# Altium schematic sheet Sheet6.SchDoc
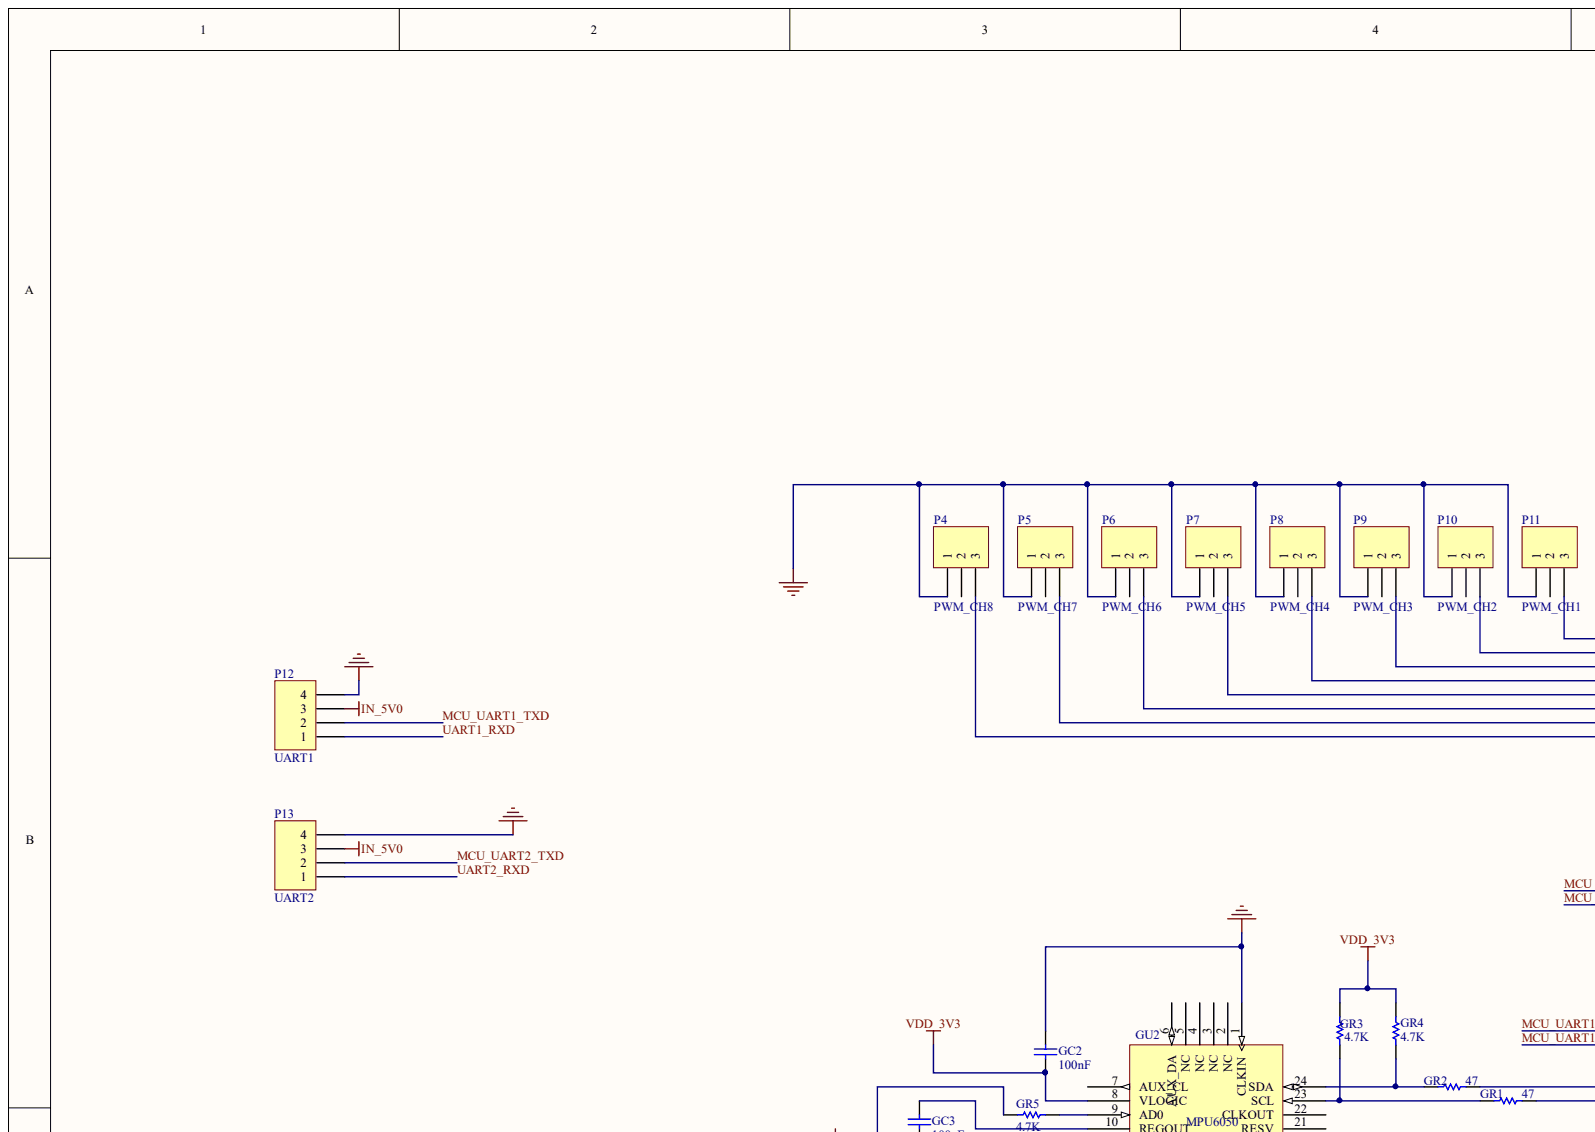
[diagram p0: Sheet6.SchDoc, top left]
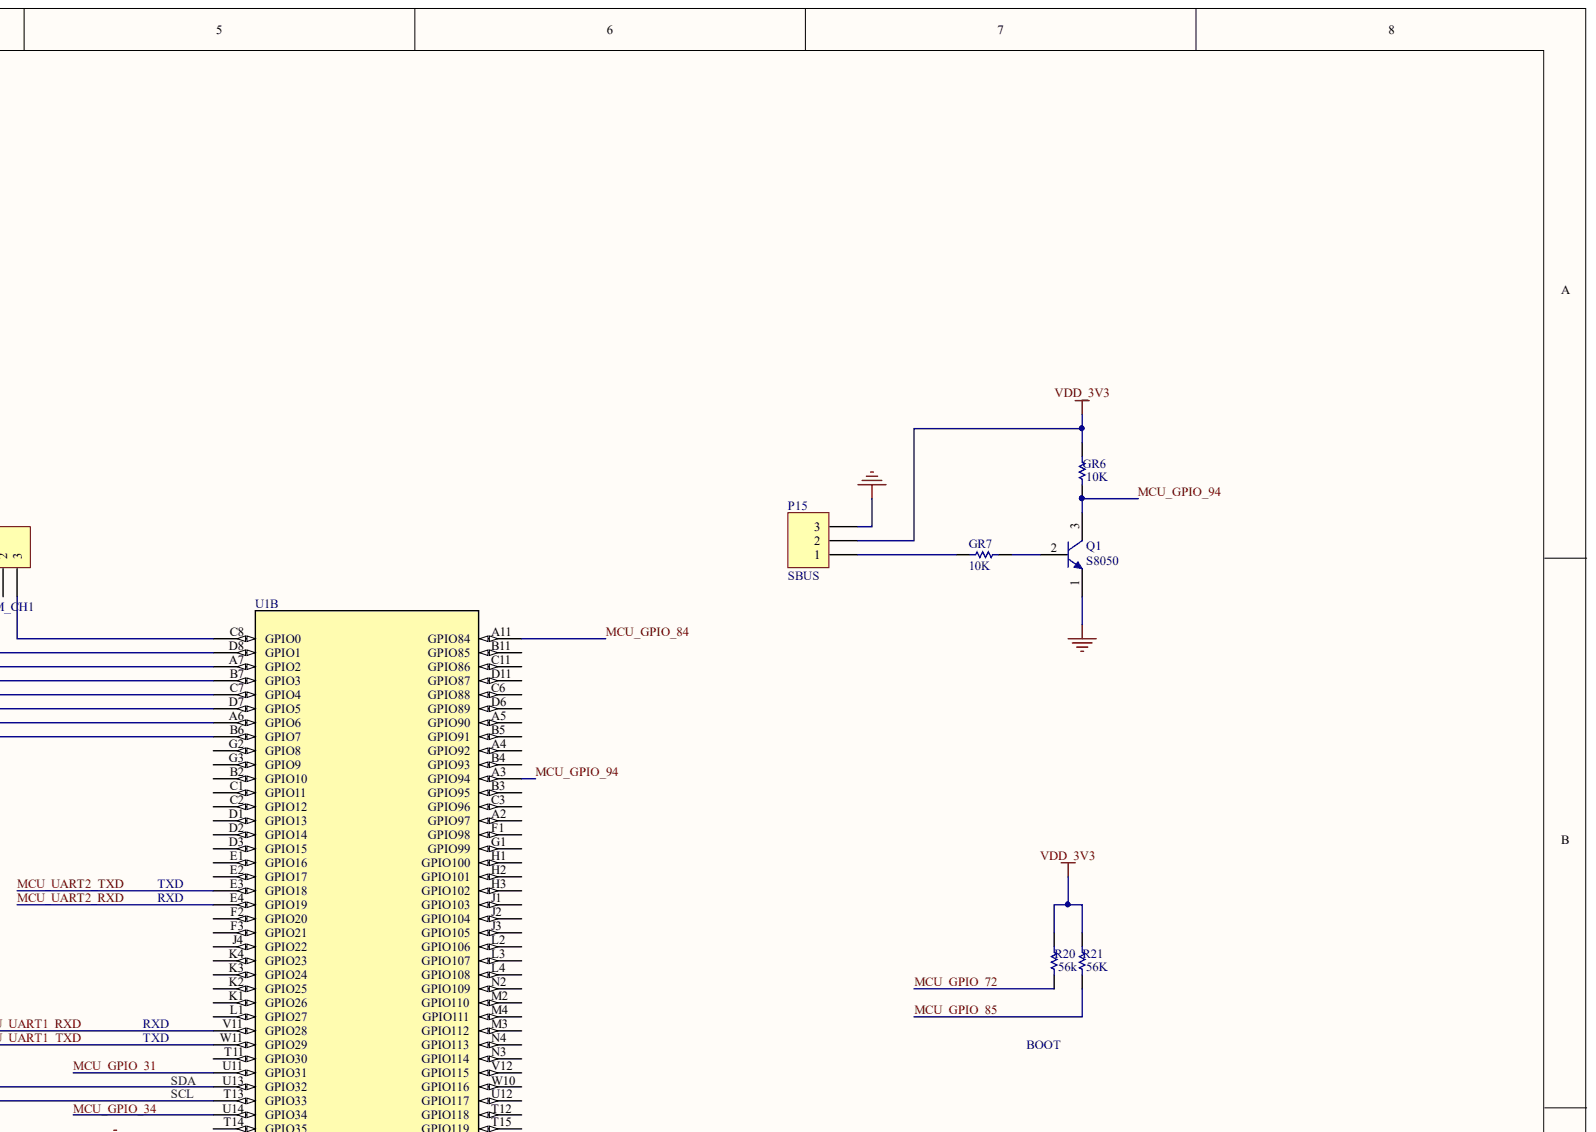
[diagram p1: Sheet6.SchDoc, top right]
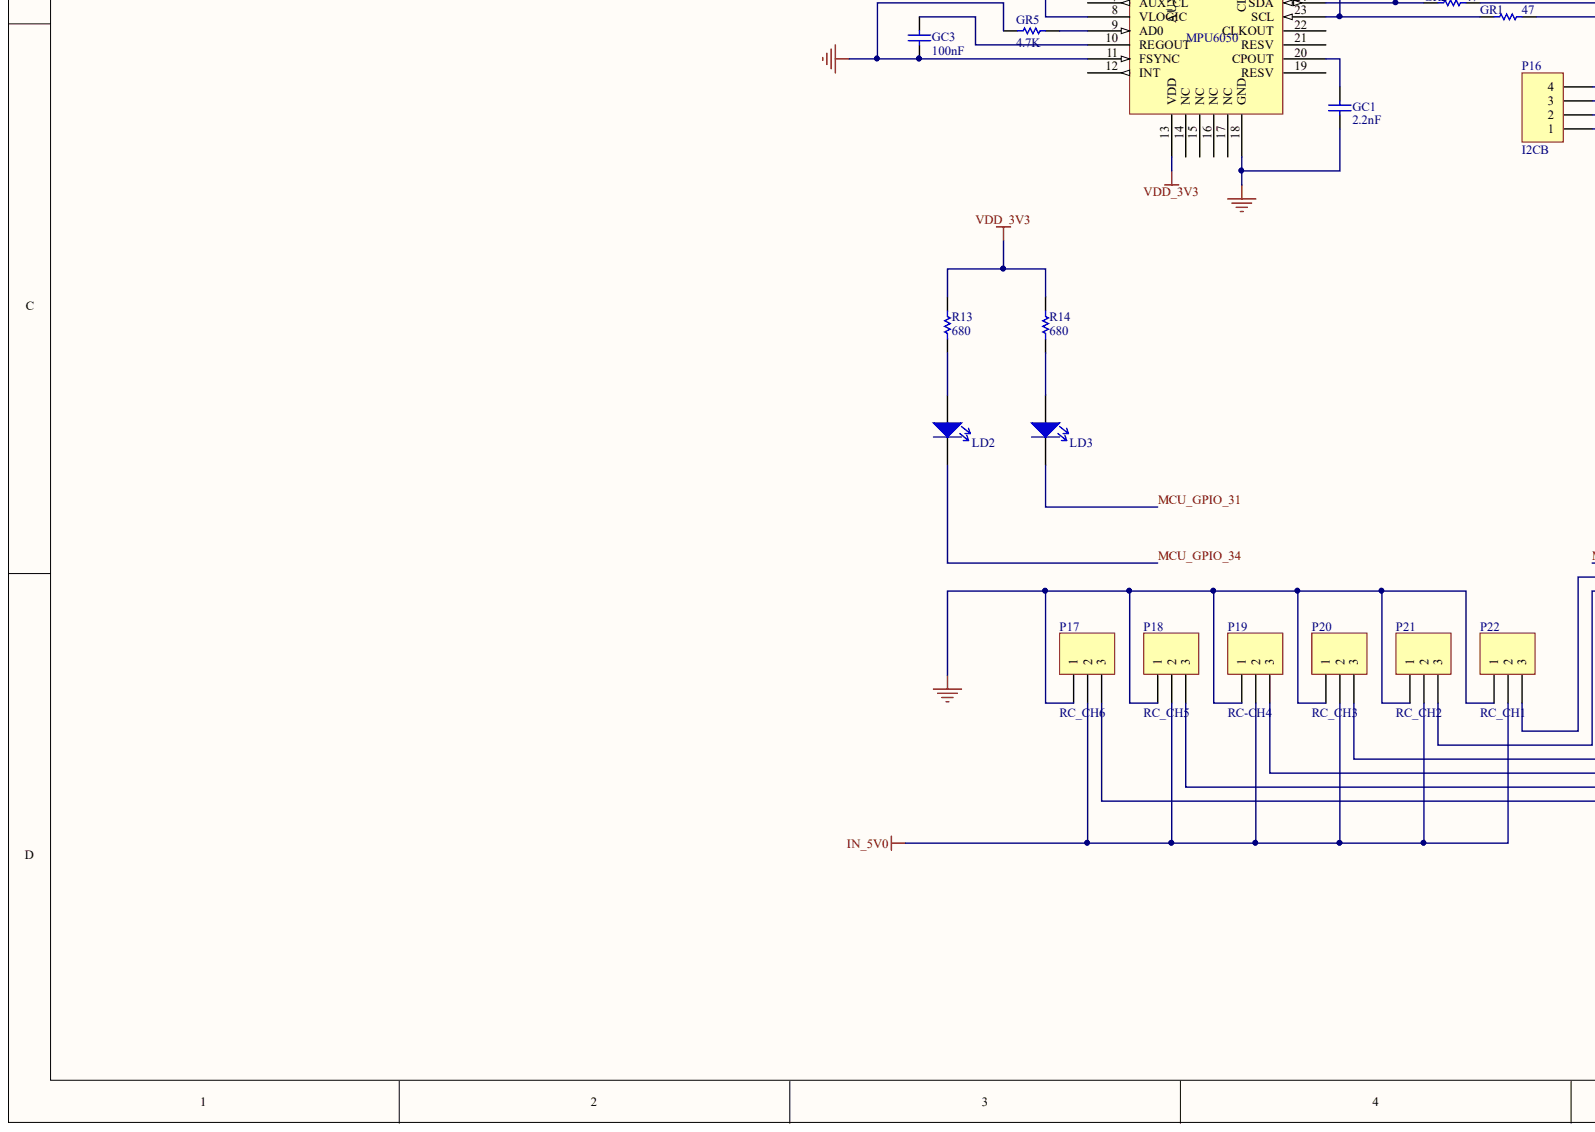
[diagram p2: Sheet6.SchDoc, bottom left]
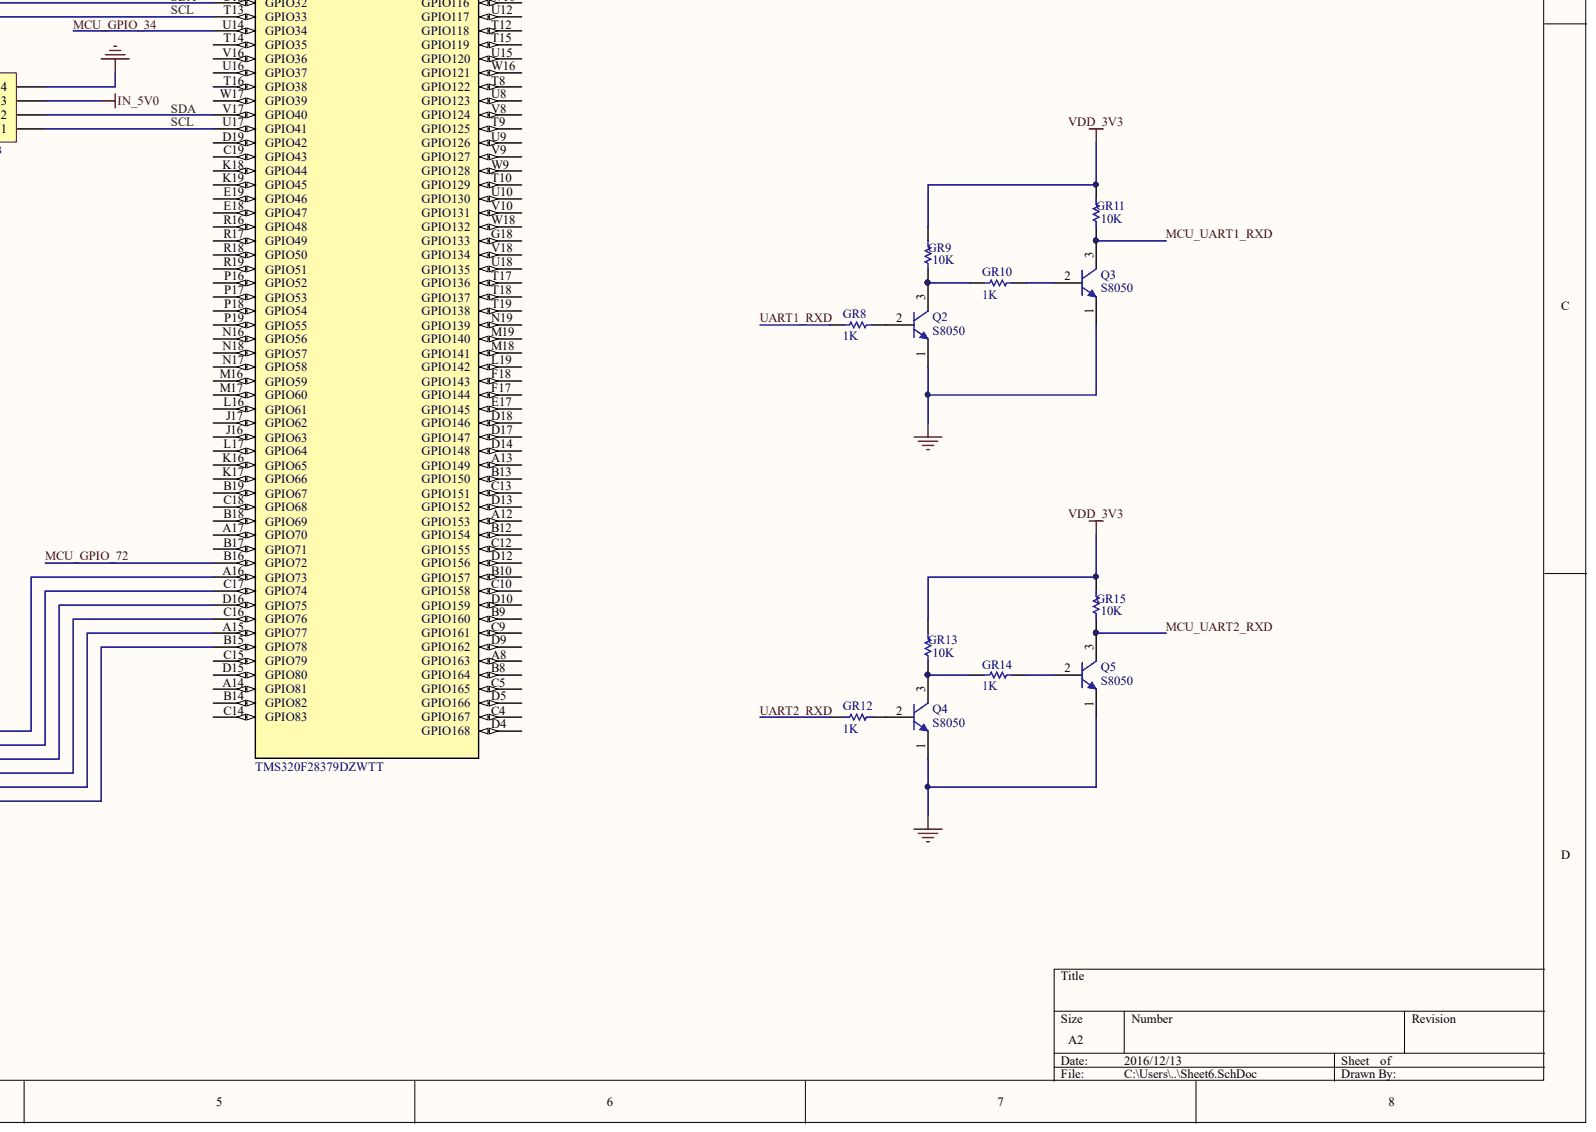
[diagram p3: Sheet6.SchDoc, bottom right]

## Parts
GC1  value 2.2nF  [0805_TW]  (hidden comment: C_0805)
GC2  value 100nF  [0805_TW]  (hidden comment: C_0805)
GC3  value 100nF  [0805_TW]  (hidden comment: C_0805)
GR1  value 47  [0402_TW]  (hidden comment: R_0402)
GR2  value 47  [0402_TW]  (hidden comment: R_0402)
GR3  value 4.7K  [0402_TW]  (hidden comment: R_0402)
GR4  value 4.7K  [0402_TW]  (hidden comment: R_0402)
GR5  value 4.7K  [0402_TW]  (hidden comment: R_0402)
GR6  value 10K  [0402_TW]  (hidden comment: R_0402)
GR7  value 10K  [0402_TW]  (hidden comment: R_0402)
GR8  value 1K  [0402_TW]  (hidden comment: R_0402)
GR9  value 10K  [0402_TW]  (hidden comment: R_0402)
GR10  value 1K  [0402_TW]  (hidden comment: R_0402)
GR11  value 10K  [0402_TW]  (hidden comment: R_0402)
GR12  value 1K  [0402_TW]  (hidden comment: R_0402)
GR13  value 10K  [0402_TW]  (hidden comment: R_0402)
GR14  value 1K  [0402_TW]  (hidden comment: R_0402)
GR15  value 10K  [0402_TW]  (hidden comment: R_0402)
GU2  MPU6050
LD2  LED_Lights  [LED-0805_TW]  (value not drawn)
LD3  LED_Lights  [LED-0805_TW]  (value not drawn)
P4  PWM_CH8  [HDR1X3]
P5  PWM_CH7  [HDR1X3]
P6  PWM_CH6  [HDR1X3]
P7  PWM_CH5  [HDR1X3]
P8  PWM_CH4  [HDR1X3]
P9  PWM_CH3  [HDR1X3]
P10  PWM_CH2  [HDR1X3]
P11  PWM_CH1  [HDR1X3]
P12  UART1  [HDR1X4]
P13  UART2  [HDR1X4]
P15  SBUS  [HDR1X3]
P16  I2CB  [HDR1X4]
P17  RC_CH6  [HDR1X3]
P18  RC_CH5  [HDR1X3]
P19  RC-CH4  [HDR1X3]
P20  RC_CH3  [HDR1X3]
P21  RC_CH2  [HDR1X3]
P22  RC_CH1  [HDR1X3]
Q1  S8050  [TO-92A]
Q2  S8050  [TO-92A]
Q3  S8050  [TO-92A]
Q4  S8050  [TO-92A]
Q5  S8050  [TO-92A]
R13  value 680  [0402_TW]  (hidden comment: R_0402)
R14  value 680  [0402_TW]  (hidden comment: R_0402)
R20  value 56k  [0402_TW]  (hidden comment: R_0402)
R21  value 56K  [0402_TW]  (hidden comment: R_0402)
U1  TMS320F28379DZWTT  [ZWT0337A]  (part B)

## Nets
GND: GC1.2 GC2.1 GC3.2 GR5.2 GU2.1(CLKIN) GU2.11(FSYNC) GU2.18(GND) P4.1 P5.1 P6.1 P7.1 P8.1 P9.1 P10.1 P11.1 P12.4 P13.4 P15.3 P16.4 P17.1 P18.1 P19.1 P20.1 P21.1 P22.1 Q1.1 Q2.1 Q3.1 Q4.1 Q5.1
IN_5V0: P12.3 P13.3 P16.3 P17.2 P18.2 P19.2 P20.2 P21.2 P22.2
VDD_3V3: GC2.2 GR3.2 GR4.2 GR6.2 GR9.2 GR11.2 GR13.2 GR15.2 GU2.8(VLOGIC) GU2.13(VDD) P15.2 R13.2 R14.2 R20.2 R21.2
MCU_GPIO_31: LD3.2 U1.U11(GPIO31)
MCU_GPIO_34: LD2.2 U1.U14(GPIO34)
MCU_GPIO_72: R20.1 U1.B16(GPIO72)
MCU_GPIO_84: U1.A11(GPIO84)
MCU_GPIO_85: R21.1
MCU_GPIO_94: GR6.1 Q1.3 U1.A3(GPIO94)
MCU_UART1_RXD: GR11.1 Q3.3 U1.V11(GPIO28)
MCU_UART1_TXD: P12.2 U1.W11(GPIO29)
MCU_UART2_RXD: GR15.1 Q5.3 U1.E4(GPIO19)
MCU_UART2_TXD: P13.2 U1.E3(GPIO18)
UART1_RXD: GR8.2 P12.1
UART2_RXD: GR12.2 P13.1
NetGC1_1: GC1.1 GU2.20(CPOUT)
NetGC3_1: GC3.1 GU2.10(REGOUT)
NetGR1_1: GR1.1 GR3.1 GU2.23(SCL)
NetGR1_2: GR1.2 U1.T13(GPIO33)
NetGR2_1: GR2.1 GR4.1 GU2.24(SDA)
NetGR2_2: GR2.2 U1.U13(GPIO32)
NetGR5_1: GR5.1 GU2.9(AD0)
NetGR7_1: GR7.1 Q1.2
NetGR7_2: GR7.2 P15.1
NetGR8_1: GR8.1 Q2.2
NetGR9_1: GR9.1 GR10.2 Q2.3
NetGR10_1: GR10.1 Q3.2
NetGR12_1: GR12.1 Q4.2
NetGR13_1: GR13.1 GR14.2 Q4.3
NetGR14_1: GR14.1 Q5.2
NetLD2_1: LD2.1 R13.1
NetLD3_1: LD3.1 R14.1
NetP4_3: P4.3 U1.B6(GPIO7)
NetP5_3: P5.3 U1.A6(GPIO6)
NetP6_3: P6.3 U1.D7(GPIO5)
NetP7_3: P7.3 U1.C7(GPIO4)
NetP8_3: P8.3 U1.B7(GPIO3)
NetP9_3: P9.3 U1.A7(GPIO2)
NetP10_3: P10.3 U1.D8(GPIO1)
NetP11_3: P11.3 U1.C8(GPIO0)
NetP16_1: P16.1 U1.U17(GPIO41)
NetP16_2: P16.2 U1.V17(GPIO40)
NetP17_3: P17.3 U1.B15(GPIO78)
NetP18_3: P18.3 U1.A15(GPIO77)
NetP19_3: P19.3 U1.C16(GPIO76)
NetP20_3: P20.3 U1.D16(GPIO75)
NetP21_3: P21.3 U1.C17(GPIO74)
NetP22_3: P22.3 U1.A16(GPIO73)

Not connected: GU2 2(NC) 3(NC) 4(NC) 5(NC) 6(AUX_DA) 7(AUX_CL) 12(INT) 14(NC) 15(NC) 16(NC) 17(NC) 19(RESV) 21(RESV) 22(CLKOUT); P4 2; P5 2; P6 2; P7 2; P8 2; P9 2; P10 2; P11 2; U1 A2(GPIO97) A4(GPIO92) A5(GPIO90) A8(GPIO163) A12(GPIO153) A13(GPIO149) A14(GPIO81) A17(GPIO70) B2(GPIO10) B3(GPIO95) B4(GPIO93) B5(GPIO91) B8(GPIO164) B9(GPIO160) B10(GPIO157) B11(GPIO85) B12(GPIO154) B13(GPIO150) B14(GPIO82) B17(GPIO71) B18(GPIO69) B19(GPIO67) C1(GPIO11) C2(GPIO12) C3(GPIO96) C4(GPIO167) C5(GPIO165) C6(GPIO88) C9(GPIO161) C10(GPIO158) C11(GPIO86) C12(GPIO155) C13(GPIO151) C14(GPIO83) C15(GPIO79) C18(GPIO68) C19(GPIO43) D1(GPIO13) D2(GPIO14) D3(GPIO15) D4(GPIO168) D5(GPIO166) D6(GPIO89) D9(GPIO162) D10(GPIO159) D11(GPIO87) D12(GPIO156) D13(GPIO152) D14(GPIO148) D15(GPIO80) D17(GPIO147) D18(GPIO146) D19(GPIO42) E1(GPIO16) E2(GPIO17) E17(GPIO145) E18(GPIO47) E19(GPIO46) F1(GPIO98) F2(GPIO20) F3(GPIO21) F17(GPIO144) F18(GPIO143) G1(GPIO99) G2(GPIO8) G3(GPIO9) G18(GPIO133) H1(GPIO100) H2(GPIO101) H3(GPIO102) J1(GPIO103) J2(GPIO104) J3(GPIO105) J4(GPIO22) J16(GPIO63) J17(GPIO62) K1(GPIO26) K2(GPIO25) K3(GPIO24) K4(GPIO23) K16(GPIO65) K17(GPIO66) K18(GPIO44) K19(GPIO45) L1(GPIO27) L2(GPIO106) L3(GPIO107) L4(GPIO108) L16(GPIO61) L17(GPIO64) L19(GPIO142) M2(GPIO110) M3(GPIO112) M4(GPIO111) M16(GPIO59) M17(GPIO60) M18(GPIO141) M19(GPIO140) N2(GPIO109) N3(GPIO114) N4(GPIO113) N16(GPIO56) N17(GPIO58) N18(GPIO57) N19(GPIO139) P16(GPIO52) P17(GPIO53) P18(GPIO54) P19(GPIO55) R16(GPIO48) R17(GPIO49) R18(GPIO50) R19(GPIO51) T8(GPIO122) T9(GPIO125) T10(GPIO129) T11(GPIO30) T12(GPIO118) T14(GPIO35) T15(GPIO119) T16(GPIO38) T17(GPIO136) T18(GPIO137) T19(GPIO138) U8(GPIO123) U9(GPIO126) U10(GPIO130) U12(GPIO117) U15(GPIO120) U16(GPIO37) U18(GPIO135) V8(GPIO124) V9(GPIO127) V10(GPIO131) V12(GPIO115) V16(GPIO36) V18(GPIO134) W9(GPIO128) W10(GPIO116) W16(GPIO121) W17(GPIO39) W18(GPIO132)

## Library data of the parts
P4-P11 P15 P17-P22 = Header 3: Header, 3-Pin; Component Kind: Standard; LatestRevisionDate: 17-Jul-2002; LatestRevisionNote: Re-released for DXP Platform.; Library Name: Miscellaneous Connectors.IntLib; Signal Integrity: Connector; Publisher: Altium Limited
P12 P13 P16 = Header 4: Header, 4-Pin; Component Kind: Standard; LatestRevisionDate: 17-Jul-2002; LatestRevisionNote: Re-released for DXP Platform.; Library Name: Miscellaneous Connectors.IntLib; Signal Integrity: Connector; Publisher: Altium Limited
Q1-Q5 = S8050: NPN三极管; Code_JEDEC: TO-92A; Component Kind: Standard; ComponentLink1Description: Manufacturer Link; ComponentLink1URL: http://www.fairchildsemi.com/; ComponentLink2Description: Datasheet; ComponentLink2URL: http://www.fairchildsemi.com/ds/2N%2F2N3904.pdf; DatasheetDocument: 1997; LatestRevisionDate: 15-Jan-2003; LatestRevisionNote: PCB Footprint 'BCY-W3/D4.7' replaced; PackageDocument: Sep-1998; PackageReference: TO-92A; Published: 8-Jun-2000; Library Name: fszhang.SchLib; Publisher: Altium Limited
U1 = TMS320F28379DZWTT: Imported; Manufacturer: Texas Instruments; Component Kind: Standard; Library Name: TMS320F28379DZWTT.SchLib; PartNumber: TMS320F28379DZWTT; RefDes: RefDes; Type: DEV; Value: Value
GC1-GC3 = C_0805: Component Kind: Standard; Library Name: TW_Lib.SchLib
GR1-GR15 R13 R14 R20 R21 = R_0402: Component Kind: Standard; Library Name: TW_Lib.SchLib
GU2 = MPU6050: Component Kind: Standard; Library Name: gtc.SchLib
LD2 LD3 = LED_Lights: Component Kind: Standard; Library Name: TW_Lib.SchLib
